# Altium schematic sheet plp-ctop_2a.SchDoc
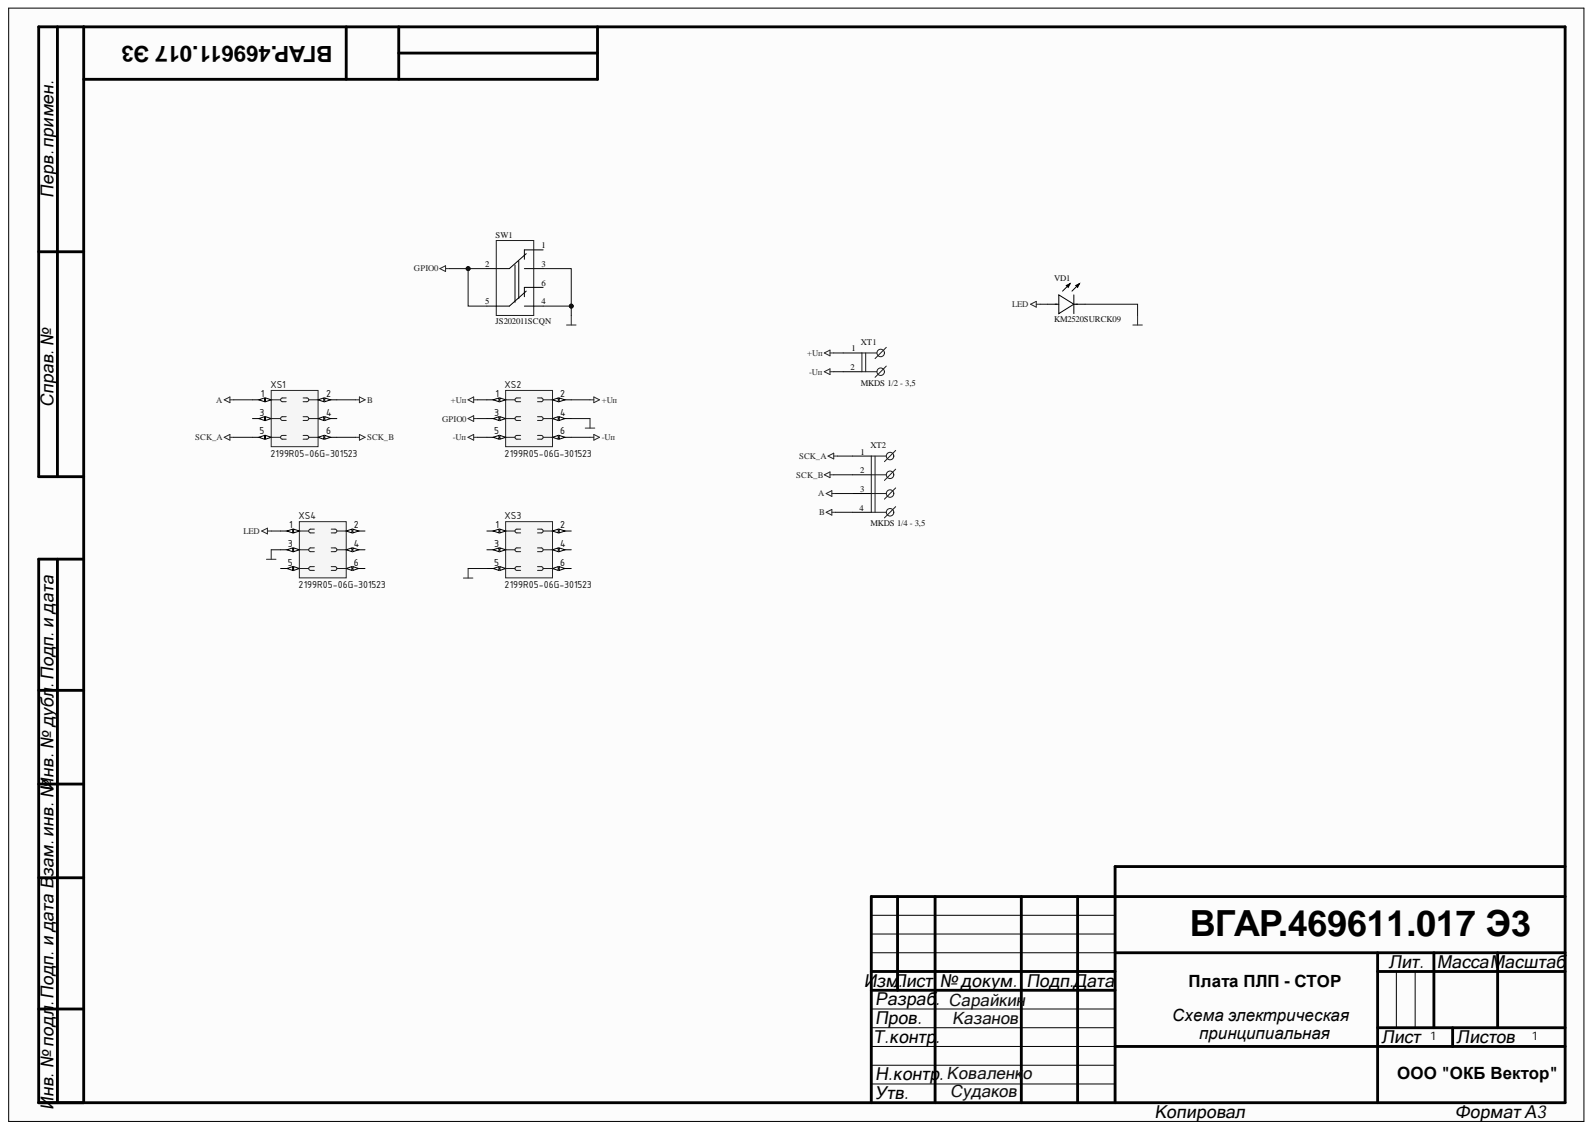
[diagram p0: plp-ctop_2a.SchDoc]

## Parts
SW1  JS202011SCQN  [JS202011SCQN]
VD1  KM2520SURCK09  [KM2520SURCK09]
XS1  2199R05-06G-301523  [CON: PLL-6(2x3R1.27female)]
XS2  2199R05-06G-301523  [CON: PLL-6(2x3R1.27female)]
XS3  2199R05-06G-301523  [CON: PLL-6(2x3R1.27female)]
XS4  2199R05-06G-301523  [CON: PLL-6(2x3R1.27female)]
XT1  MKDS 1/2 - 3,5  [MKDS 1/2 - 3,5]
XT2  MKDS 1/4 - 3,5  [MKDS 1/4 - 3,5]

## Nets
+Uп: XS2.1 XS2.2 XT1.1
-Uп: XS2.5 XS2.6 XT1.2
A: XS1.1 XT2.3
B: XS1.2 XT2.4
GND: SW1.3 SW1.4 VD1.2 XS2.4 XS3.5 XS4.3
GPIO0: SW1.2 SW1.5 XS2.3
LED: VD1.1 XS4.1
SCK_A: XS1.5 XT2.1
SCK_B: XS1.6 XT2.2

Not connected: SW1 1 6; XS1 3 4; XS3 1 2 3 4 6; XS4 2 4 5 6

## Library data of the parts
SW1 = JS202011SCQN: Переключатель; Manufacturer: C&K Component; ReplacementPart1Manufacturer: Китай; ReplacementPart1PartNumber: is-1390; Component Kind: Standard; ComponentLink1Description: Data Sheet; ComponentLink1URL: http://www.tycoelectronics.com/catalog/pn/en/1571983-4?RQPN=1571983-4; ComponentLink2Description: Replacement 1 DataSheet; Library Name: Switch.IntLib
VD1 = KM2520SURCK09: LED; Component Kind: Standard; Library Name: Kingbright LED.IntLib
XS1-XS4 = 2199R05-06G-301523In: Step 1.27; Component Kind: Standard; Library Name: connector.IntLib
XT1 = MKDS 1/2 - 3,5: Component Kind: Standard; Library Name: Phoenixcontact Terminal Block.IntLib
XT2 = MKDS 1/4 - 3,5: Component Kind: Standard; ComponentLink1Description: Datasheet; ComponentLink1URL: https://www.phoenixcontact.com/online/portal/ru?uri=pxc-oc-itemdetail:pid=1751248&library=ruru&tab=1; Library Name: Phoenixcontact Terminal Block.IntLib
